# Altium schematic sheet （5）CLOCK & JTAG & VCC.Sch
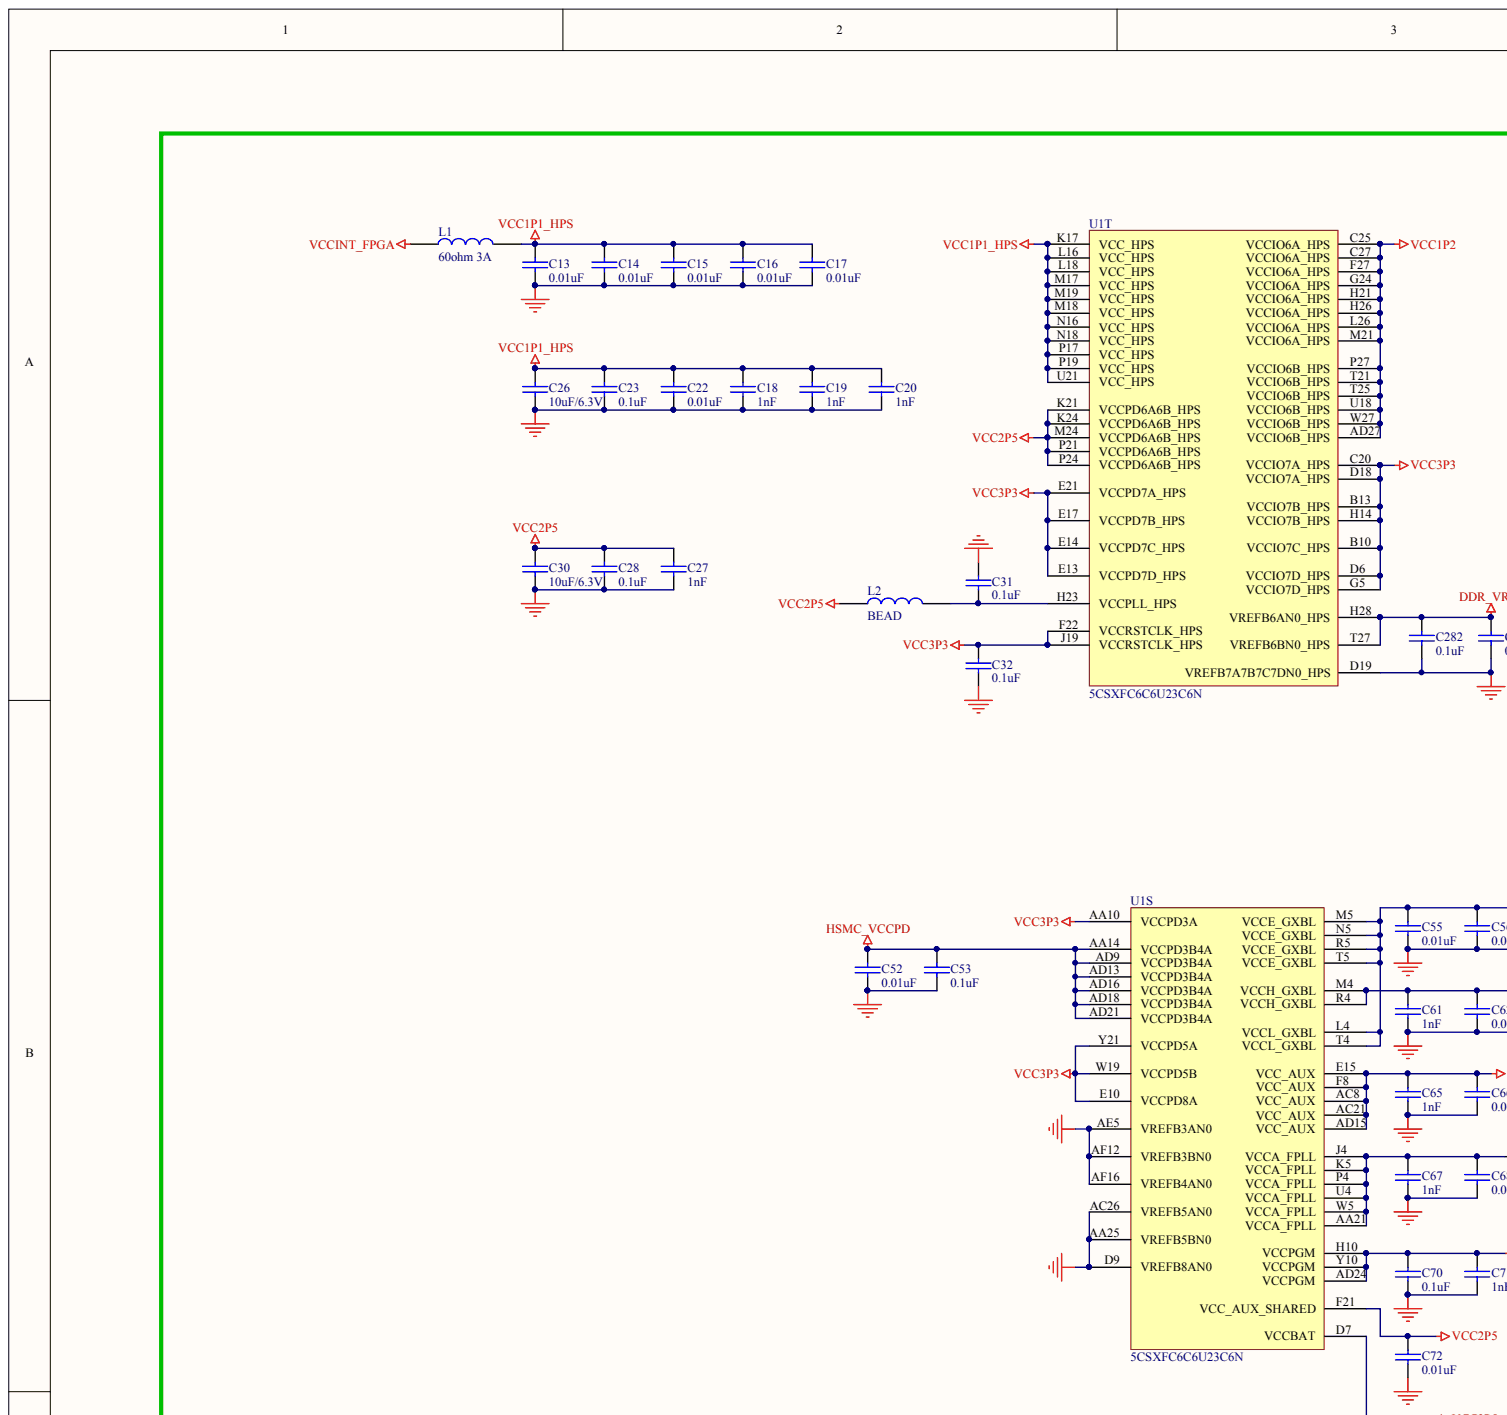
[diagram p0: （5）CLOCK & JTAG & VCC.Sch, top left]
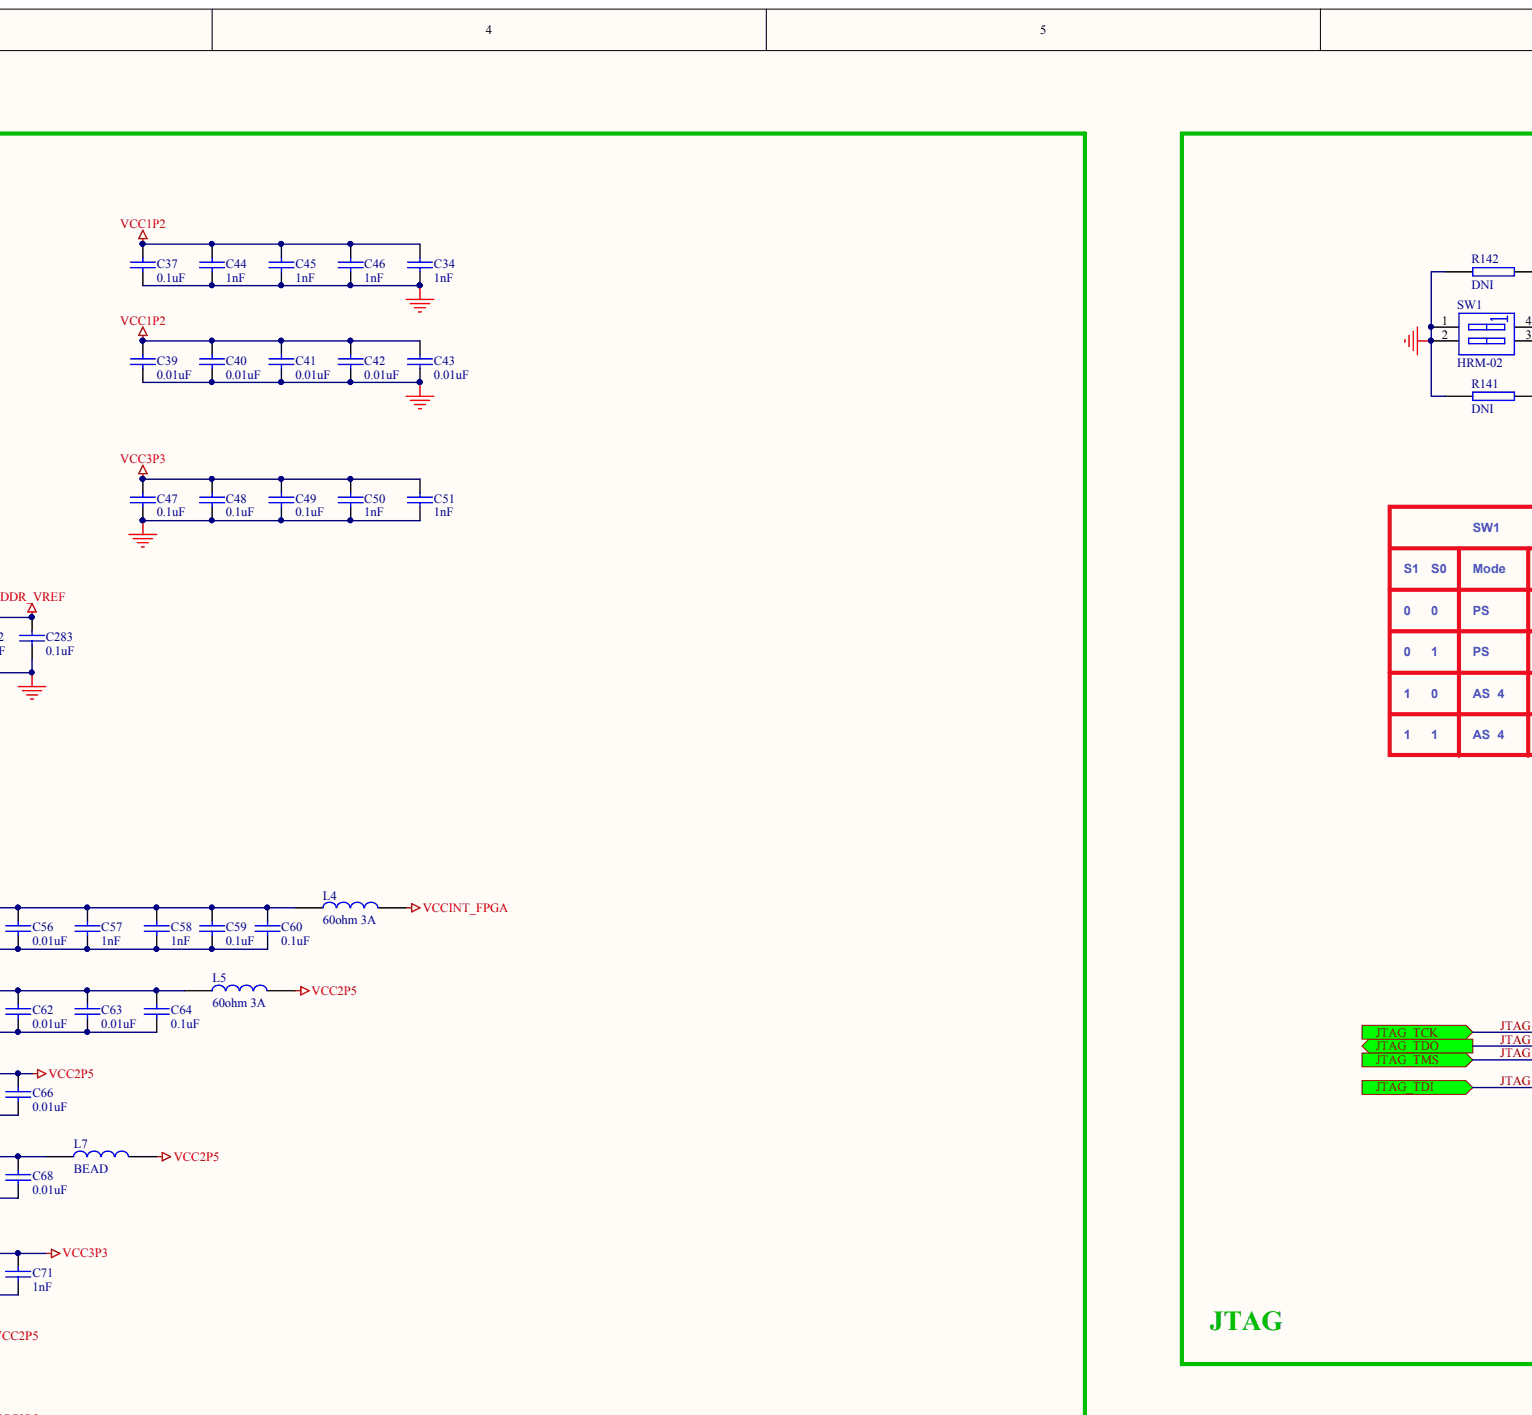
[diagram p1: （5）CLOCK & JTAG & VCC.Sch, top centre]
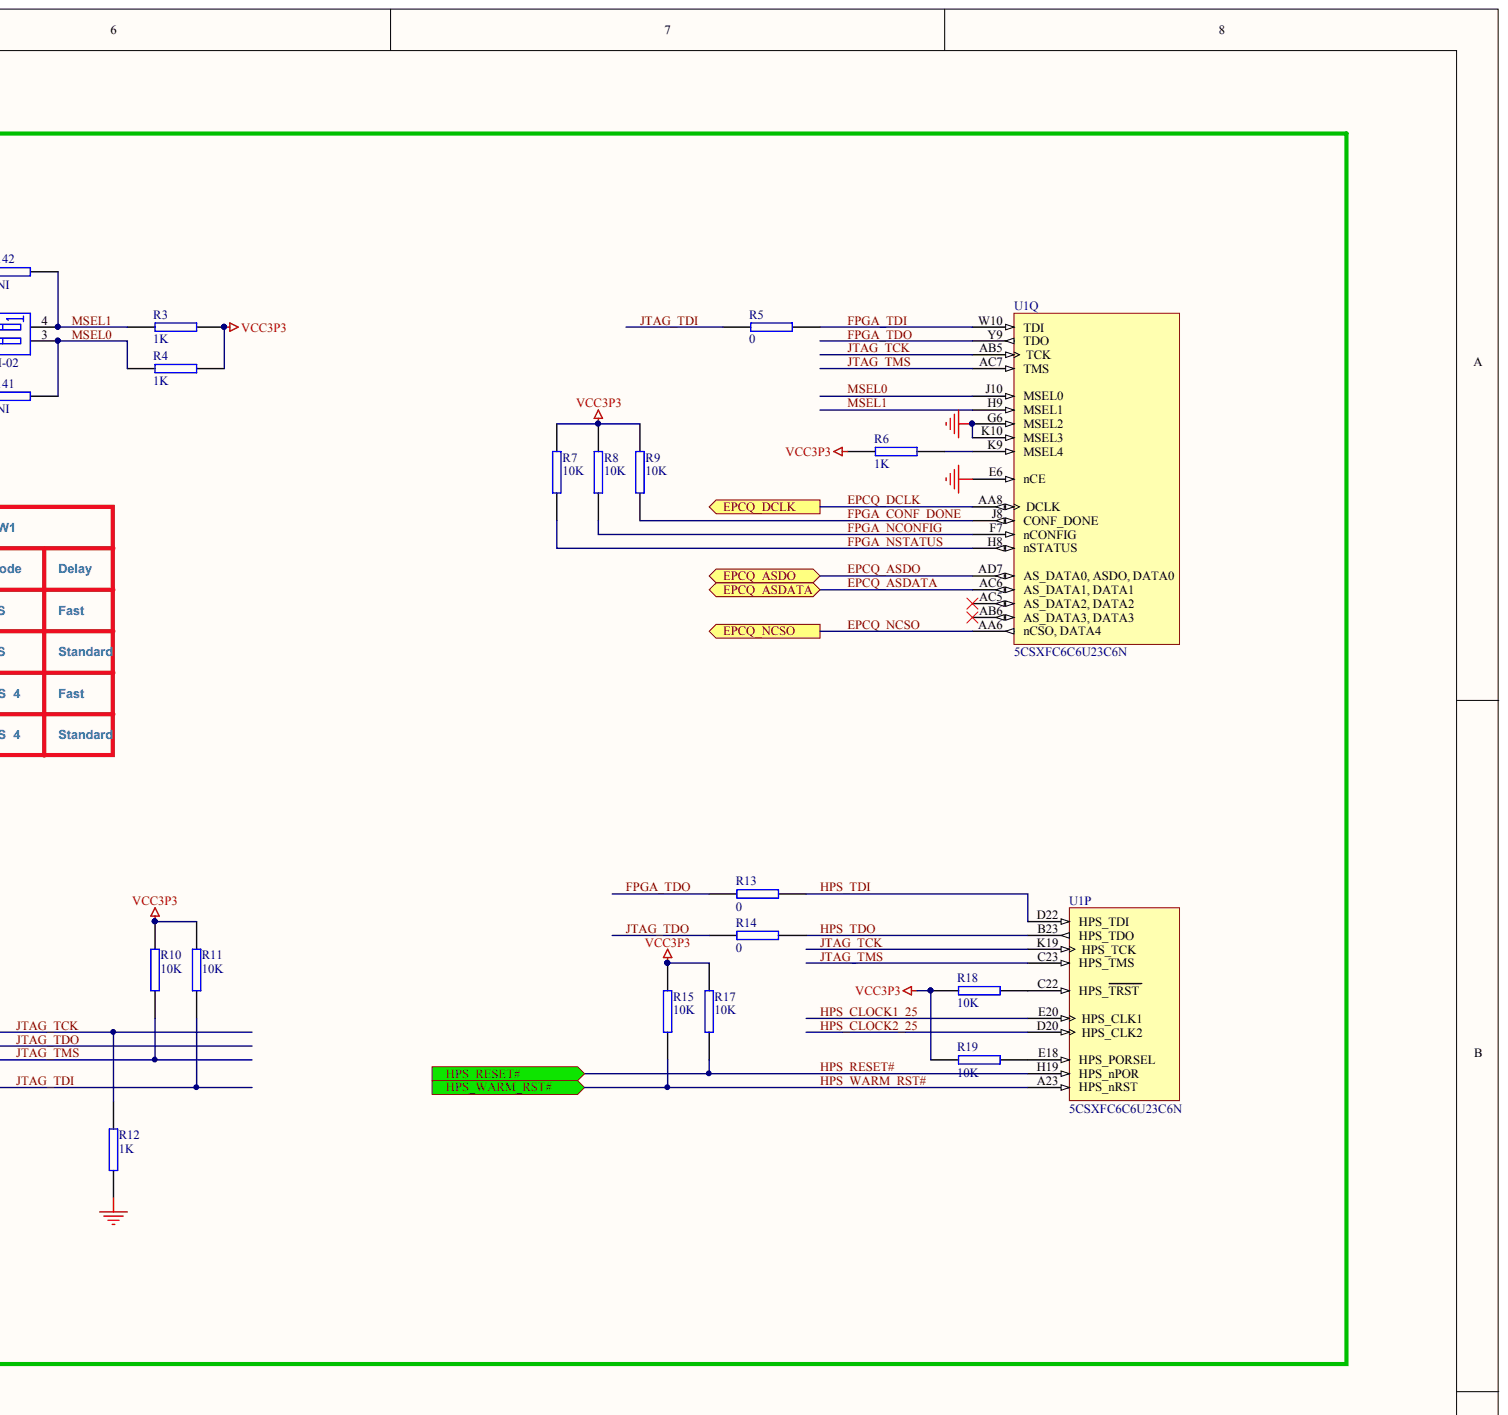
[diagram p2: （5）CLOCK & JTAG & VCC.Sch, top right]
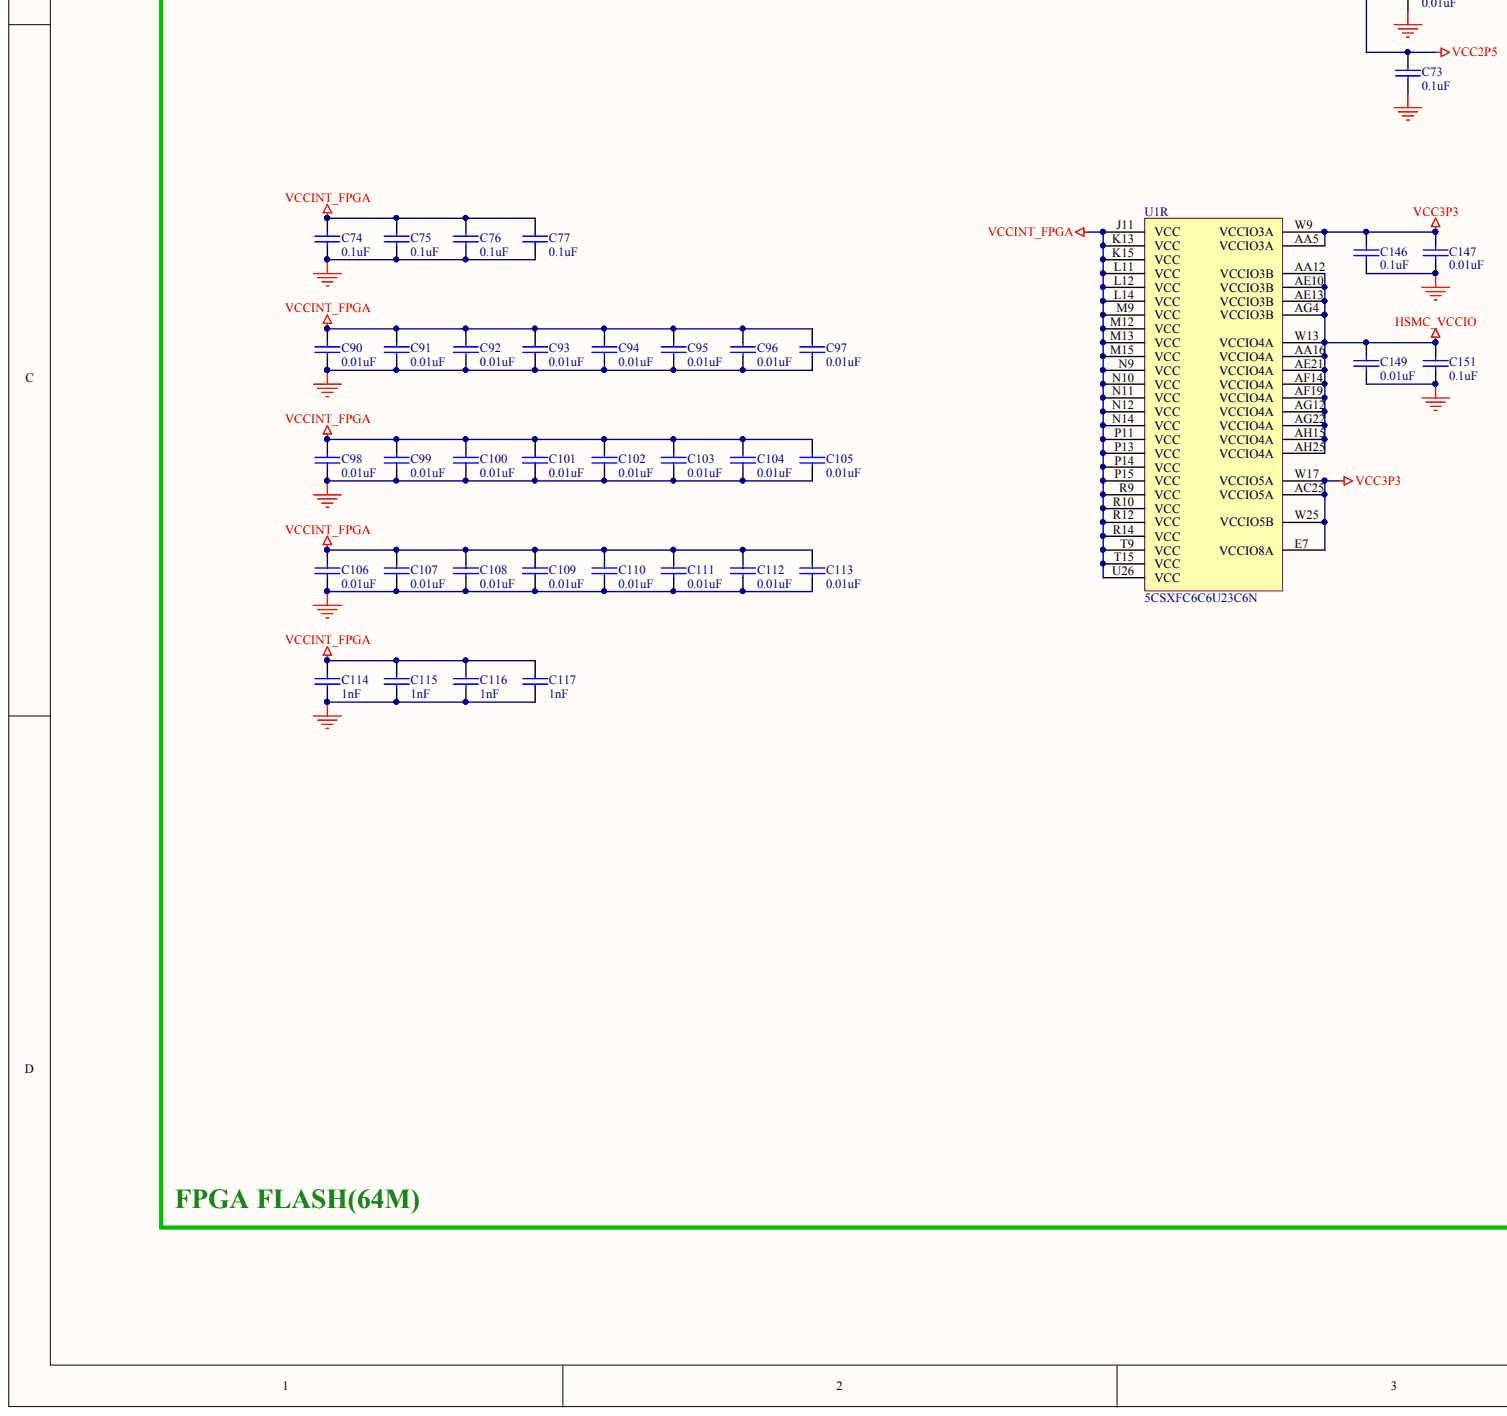
[diagram p3: （5）CLOCK & JTAG & VCC.Sch, bottom left]
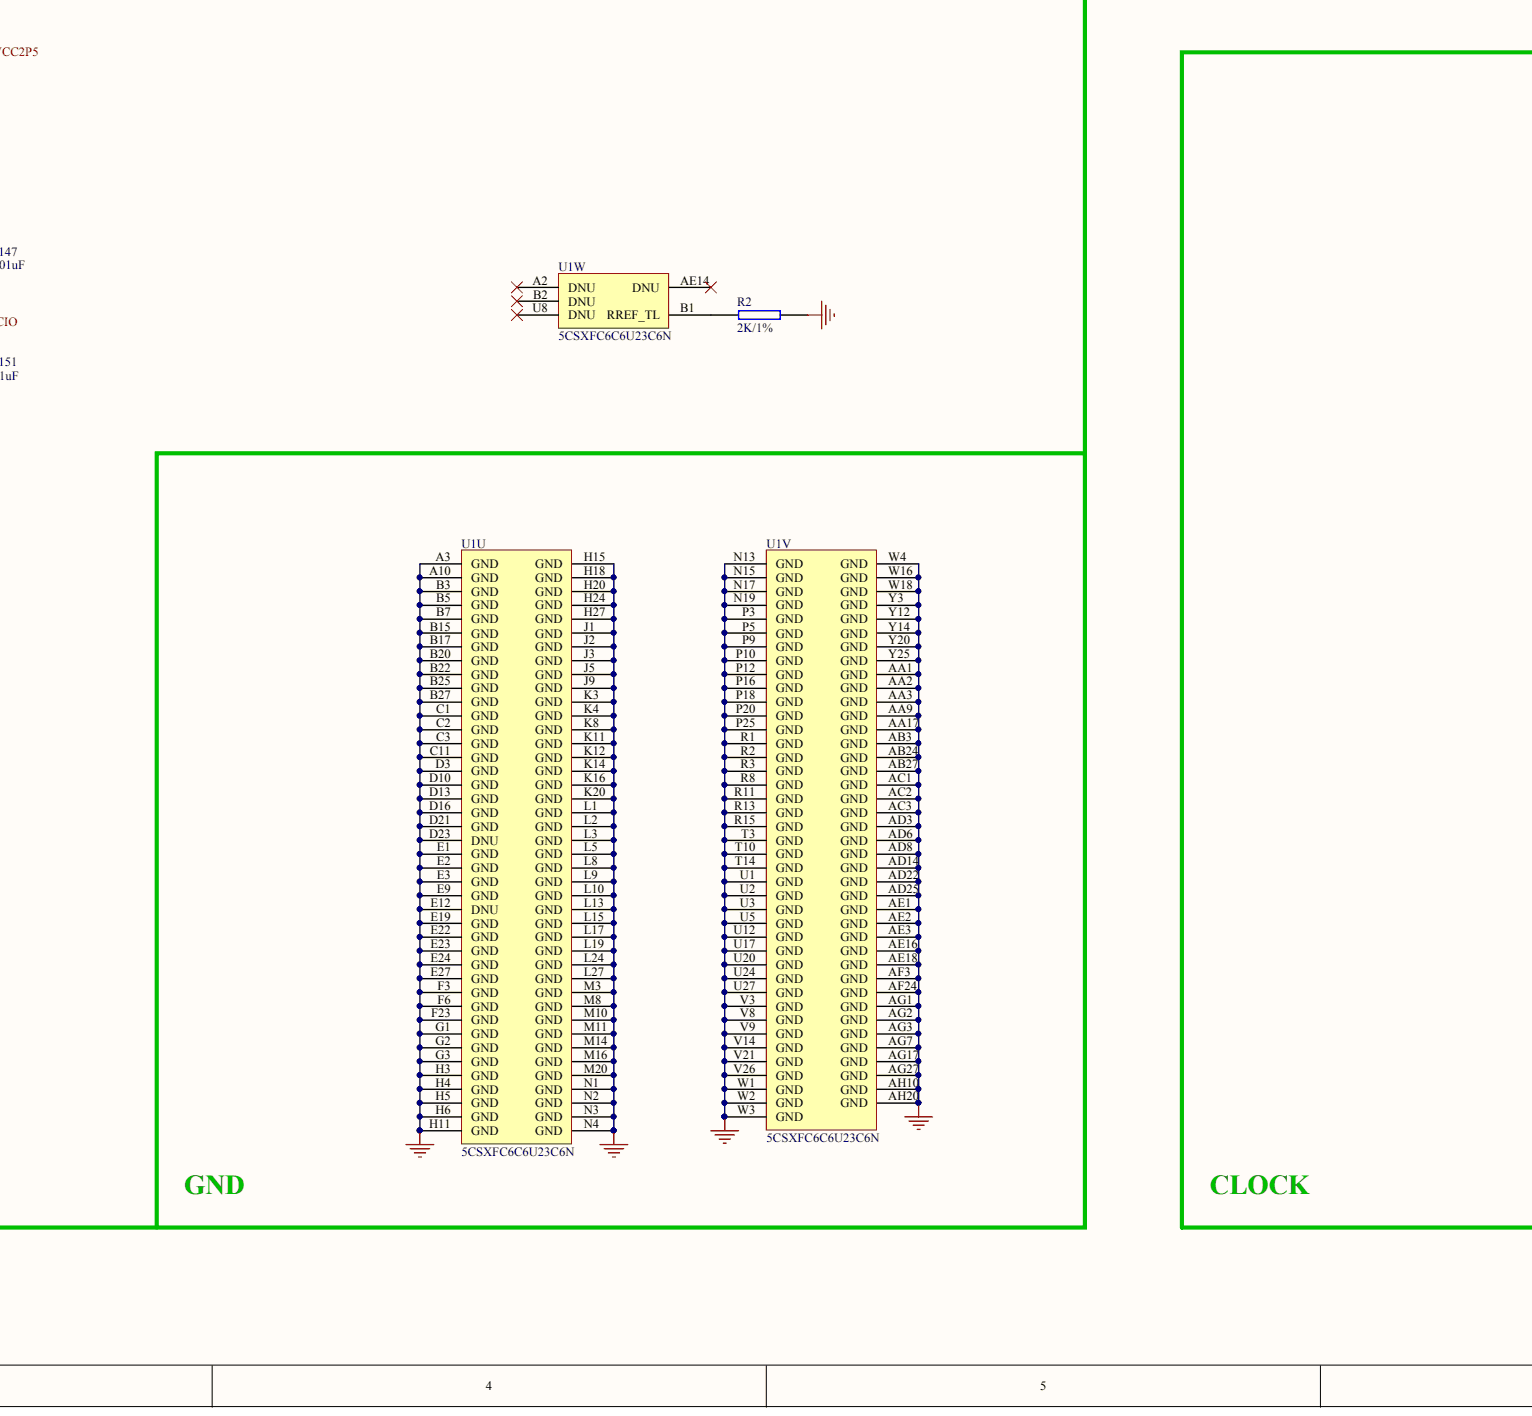
[diagram p4: （5）CLOCK & JTAG & VCC.Sch, bottom centre]
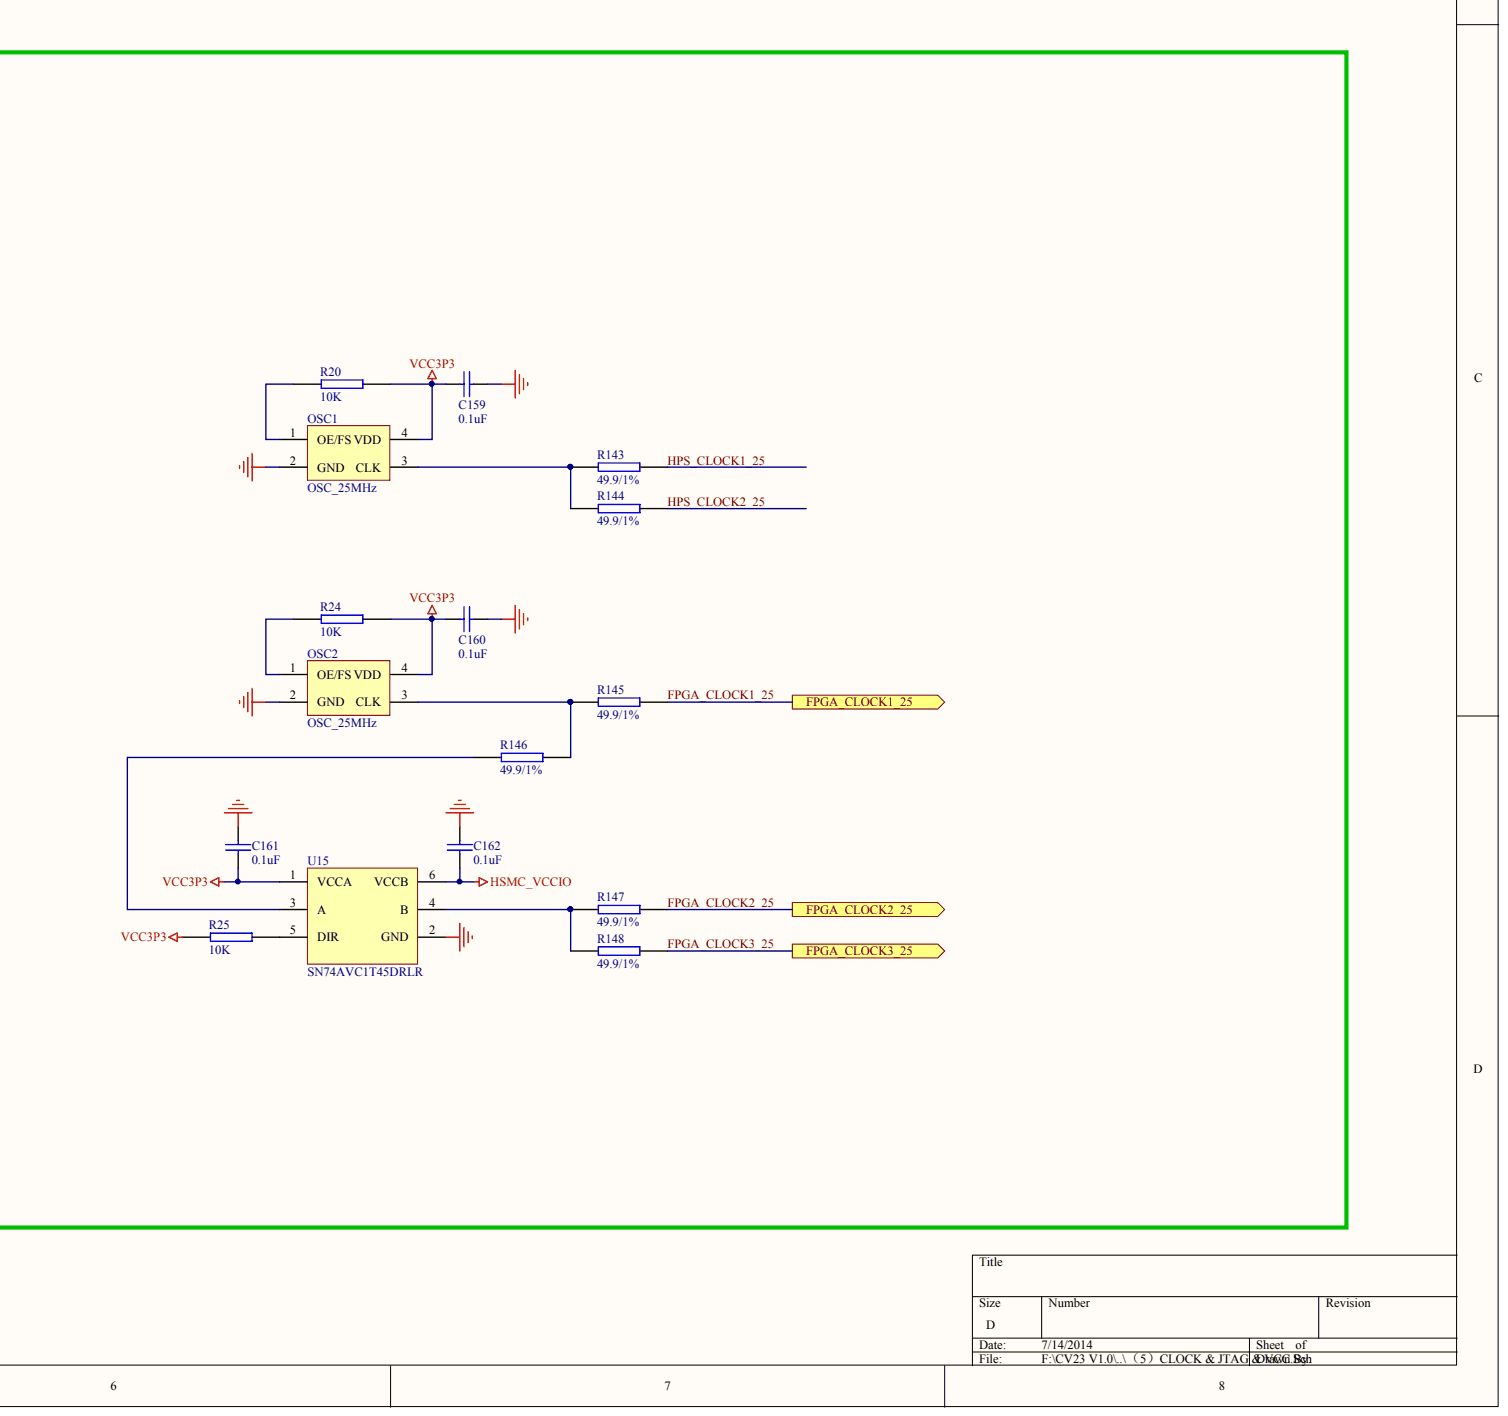
[diagram p5: （5）CLOCK & JTAG & VCC.Sch, bottom right]

## Parts
C13  0.01uF
C14  0.01uF
C15  0.01uF
C16  0.01uF
C17  0.01uF
C18  1nF
C19  1nF
C20  1nF
C22  0.01uF
C23  0.1uF
C26  10uF/6.3V
C27  1nF
C28  0.1uF
C30  10uF/6.3V
C31  0.1uF
C32  0.1uF
C34  1nF
C37  0.1uF
C39  0.01uF
C40  0.01uF
C41  0.01uF
C42  0.01uF
C43  0.01uF
C44  1nF
C45  1nF
C46  1nF
C47  0.1uF
C48  0.1uF
C49  0.1uF
C50  1nF
C51  1nF
C52  0.01uF
C53  0.1uF
C55  0.01uF
C56  0.01uF
C57  1nF
C58  1nF
C59  0.1uF
C60  0.1uF
C61  1nF
C62  0.01uF
C63  0.01uF
C64  0.1uF
C65  1nF
C66  0.01uF
C67  1nF
C68  0.01uF
C70  0.1uF
C71  1nF
C72  0.01uF
C73  0.1uF
C74  0.1uF
C75  0.1uF
C76  0.1uF
C77  0.1uF
C90  0.01uF
C91  0.01uF
C92  0.01uF
C93  0.01uF
C94  0.01uF
C95  0.01uF
C96  0.01uF
C97  0.01uF
C98  0.01uF
C99  0.01uF
C100  0.01uF
C101  0.01uF
C102  0.01uF
C103  0.01uF
C104  0.01uF
C105  0.01uF
C106  0.01uF
C107  0.01uF
C108  0.01uF
C109  0.01uF
C110  0.01uF
C111  0.01uF
C112  0.01uF
C113  0.01uF
C114  1nF
C115  1nF
C116  1nF
C117  1nF
C146  0.1uF
C147  0.01uF
C149  0.01uF
C151  0.1uF
C159  0.1uF
C160  0.1uF
C161  0.1uF
C162  0.1uF
C282  0.1uF
C283  0.1uF
L1  60ohm 3A
L2  BEAD
L4  60ohm 3A
L5  60ohm 3A
L7  BEAD
OSC1  OSC_25MHz
OSC2  OSC_25MHz
R2  2K/1%
R3  1K
R4  1K
R5  0
R6  1K
R7  10K
R8  10K
R9  10K
R10  10K
R11  10K
R12  1K
R13  0
R14  0
R15  10K
R17  10K
R18  10K
R19  10K
R20  10K
R24  10K
R25  10K
R141  DNI
R142  DNI
R143  49.9/1%
R144  49.9/1%
R145  49.9/1%
R146  49.9/1%
R147  49.9/1%
R148  49.9/1%
SW1  HRM-02
U1  5CSXFC6C6U23C6N  (parts P,Q,R,S,T,U,V,W)
U15  SN74AVC1T45DRLR

## Ports
EPCQ_ASDATA, EPCQ_ASDO, EPCQ_DCLK, EPCQ_NCSO, FPGA_CLOCK1_25, FPGA_CLOCK2_25, FPGA_CLOCK3_25, HPS_RESET#, HPS_WARM_RST#, JTAG_TCK, JTAG_TDI, JTAG_TDO, JTAG_TMS

## Nets
DDR_VREF: C282.1 C283.1 U1.H28(VREFB6AN0_HPS) U1.T27(VREFB6BN0_HPS)
GND: C13.2 C14.2 C15.2 C16.2 C17.2 C18.2 C19.2 C20.2 C22.2 C23.2 C26.2 C27.2 C28.2 C30.2 C31.1 C32.2 C34.2 C37.2 C39.2 C40.2 C41.2 C42.2 C43.2 C44.2 C45.2 C46.2 C47.2 C48.2 C49.2 C50.2 C51.2 C52.2 C53.2 C55.2 C56.2 C57.2 C58.2 C59.2 C60.2 C61.2 C62.2 C63.2 C64.2 C65.2 C66.2 C67.2 C68.2 C70.2 C71.2 C72.2 C73.2 C74.2 C75.2 C76.2 C77.2 C90.2 C91.2 C92.2 C93.2 C94.2 C95.2 C96.2 C97.2 C98.2 C99.2 C100.2 C101.2 C102.2 C103.2 C104.2 C105.2 C106.2 C107.2 C108.2 C109.2 C110.2 C111.2 C112.2 C113.2 C114.2 C115.2 C116.2 C117.2 C146.2 C147.2 C149.2 C151.2 C159.2 C160.2 C161.1 C162.1 C282.2 C283.2 OSC1.2(GND) OSC2.2(GND) R2.1 R12.1 R141.2 R142.2 SW1.1 SW1.2 U1.A3(GND) U1.A10(GND) U1.AA1(GND) U1.AA2(GND) U1.AA3(GND) U1.AA9(GND) U1.AA17(GND) U1.AA25(VREFB5BN0) U1.AB3(GND) U1.AB24(GND) U1.AB27(GND) U1.AC1(GND) U1.AC2(GND) U1.AC3(GND) U1.AC26(VREFB5AN0) U1.AD3(GND) U1.AD6(GND) U1.AD8(GND) U1.AD14(GND) U1.AD22(GND) U1.AD25(GND) U1.AE1(GND) U1.AE2(GND) U1.AE3(GND) U1.AE5(VREFB3AN0) U1.AE16(GND) U1.AE18(GND) U1.AF3(GND) U1.AF12(VREFB3BN0) U1.AF16(VREFB4AN0) U1.AF24(GND) U1.AG1(GND) U1.AG2(GND) U1.AG3(GND) U1.AG7(GND) U1.AG17(GND) U1.AG27(GND) U1.AH10(GND) U1.AH20(GND) U1.B3(GND) U1.B5(GND) U1.B7(GND) U1.B15(GND) U1.B17(GND) U1.B20(GND) U1.B22(GND) U1.B25(GND) U1.B27(GND) U1.C1(GND) U1.C2(GND) U1.C3(GND) U1.C11(GND) U1.D3(GND) U1.D9(VREFB8AN0) U1.D10(GND) U1.D13(GND) U1.D16(GND) U1.D19(VREFB7A7B7C7DN0_HPS) U1.D21(GND) U1.D23(DNU) U1.E1(GND) U1.E2(GND) U1.E3(GND) U1.E6(nCE) U1.E9(GND) U1.E12(DNU) U1.E19(GND) U1.E22(GND) U1.E23(GND) U1.E24(GND) U1.E27(GND) U1.F3(GND) U1.F6(GND) U1.F23(GND) U1.G1(GND) U1.G2(GND) U1.G3(GND) U1.G6(MSEL2) U1.H3(GND) U1.H4(GND) U1.H5(GND) U1.H6(GND) U1.H11(GND) U1.H15(GND) U1.H18(GND) U1.H20(GND) U1.H24(GND) U1.H27(GND) U1.J1(GND) U1.J2(GND) U1.J3(GND) U1.J5(GND) U1.J9(GND) U1.K3(GND) U1.K4(GND) U1.K8(GND) U1.K10(MSEL3) U1.K11(GND) U1.K12(GND) U1.K14(GND) U1.K16(GND) U1.K20(GND) U1.L1(GND) U1.L2(GND) U1.L3(GND) U1.L5(GND) U1.L8(GND) U1.L9(GND) U1.L10(GND) U1.L13(GND) U1.L15(GND) U1.L17(GND) U1.L19(GND) U1.L24(GND) U1.L27(GND) U1.M3(GND) U1.M8(GND) U1.M10(GND) U1.M11(GND) U1.M14(GND) U1.M16(GND) U1.M20(GND) U1.N1(GND) U1.N2(GND) U1.N3(GND) U1.N4(GND) U1.N13(GND) U1.N15(GND) U1.N17(GND) U1.N19(GND) U1.P3(GND) U1.P5(GND) U1.P9(GND) U1.P10(GND) U1.P12(GND) U1.P16(GND) U1.P18(GND) U1.P20(GND) U1.P25(GND) U1.R1(GND) U1.R2(GND) U1.R3(GND) U1.R8(GND) U1.R11(GND) U1.R13(GND) U1.R15(GND) U1.T3(GND) U1.T10(GND) U1.T14(GND) U1.U1(GND) U1.U2(GND) U1.U3(GND) U1.U5(GND) U1.U12(GND) U1.U17(GND) U1.U20(GND) U1.U24(GND) U1.U27(GND) U1.V3(GND) U1.V8(GND) U1.V9(GND) U1.V14(GND) U1.V21(GND) U1.V26(GND) U1.W1(GND) U1.W2(GND) U1.W3(GND) U1.W4(GND) U1.W16(GND) U1.W18(GND) U1.Y3(GND) U1.Y12(GND) U1.Y14(GND) U1.Y20(GND) U1.Y25(GND) U15.2(GND)
HSMC_VCCIO: C149.1 C151.1 C162.2 U1.AA12(VCCIO3B) U1.AA16(VCCIO4A) U1.AE10(VCCIO3B) U1.AE13(VCCIO3B) U1.AE21(VCCIO4A) U1.AF14(VCCIO4A) U1.AF19(VCCIO4A) U1.AG4(VCCIO3B) U1.AG12(VCCIO4A) U1.AG22(VCCIO4A) U1.AH15(VCCIO4A) U1.AH25(VCCIO4A) U1.W13(VCCIO4A) U15.6(VCCB)
HSMC_VCCPD: C52.1 C53.1 U1.AA14(VCCPD3B4A) U1.AD9(VCCPD3B4A) U1.AD13(VCCPD3B4A) U1.AD16(VCCPD3B4A) U1.AD18(VCCPD3B4A) U1.AD21(VCCPD3B4A)
VCC1P1_HPS: C13.1 C14.1 C15.1 C16.1 C17.1 C18.1 C19.1 C20.1 C22.1 C23.1 C26.1 L1.2 U1.K17(VCC_HPS) U1.L16(VCC_HPS) U1.L18(VCC_HPS) U1.M17(VCC_HPS) U1.M18(VCC_HPS) U1.M19(VCC_HPS) U1.N16(VCC_HPS) U1.N18(VCC_HPS) U1.P17(VCC_HPS) U1.P19(VCC_HPS) U1.U21(VCC_HPS)
VCC1P2: C34.1 C37.1 C39.1 C40.1 C41.1 C42.1 C43.1 C44.1 C45.1 C46.1 U1.AD27(VCCIO6B_HPS) U1.C25(VCCIO6A_HPS) U1.C27(VCCIO6A_HPS) U1.F27(VCCIO6A_HPS) U1.G24(VCCIO6A_HPS) U1.H21(VCCIO6A_HPS) U1.H26(VCCIO6A_HPS) U1.L26(VCCIO6A_HPS) U1.M21(VCCIO6A_HPS) U1.P27(VCCIO6B_HPS) U1.T21(VCCIO6B_HPS) U1.T25(VCCIO6B_HPS) U1.U18(VCCIO6B_HPS) U1.W27(VCCIO6B_HPS)
VCC2P5: C27.1 C28.1 C30.1 C65.1 C66.1 C72.1 C73.1 L2.1 L5.2 L7.2 U1.AC8(VCC_AUX) U1.AC21(VCC_AUX) U1.AD15(VCC_AUX) U1.D7(VCCBAT) U1.E15(VCC_AUX) U1.F8(VCC_AUX) U1.F21(VCC_AUX_SHARED) U1.K21(VCCPD6A6B_HPS) U1.K24(VCCPD6A6B_HPS) U1.M24(VCCPD6A6B_HPS) U1.P21(VCCPD6A6B_HPS) U1.P24(VCCPD6A6B_HPS)
VCC3P3: C32.1 C47.1 C48.1 C49.1 C50.1 C51.1 C70.1 C71.1 C146.1 C147.1 C159.1 C160.1 C161.2 OSC1.4(VDD) OSC2.4(VDD) R3.1 R4.1 R6.2 R7.2 R8.2 R9.2 R10.2 R11.2 R15.2 R17.1 R18.2 R19.2 R20.1 R24.1 R25.2 U1.AA5(VCCIO3A) U1.AA10(VCCPD3A) U1.AC25(VCCIO5A) U1.AD24(VCCPGM) U1.B10(VCCIO7C_HPS) U1.B13(VCCIO7B_HPS) U1.C20(VCCIO7A_HPS) U1.D6(VCCIO7D_HPS) U1.D18(VCCIO7A_HPS) U1.E7(VCCIO8A) U1.E10(VCCPD8A) U1.E13(VCCPD7D_HPS) U1.E14(VCCPD7C_HPS) U1.E17(VCCPD7B_HPS) U1.E21(VCCPD7A_HPS) U1.F22(VCCRSTCLK_HPS) U1.G5(VCCIO7D_HPS) U1.H10(VCCPGM) U1.H14(VCCIO7B_HPS) U1.J19(VCCRSTCLK_HPS) U1.W9(VCCIO3A) U1.W17(VCCIO5A) U1.W19(VCCPD5B) U1.W25(VCCIO5B) U1.Y10(VCCPGM) U1.Y21(VCCPD5A) U15.1(VCCA)
VCCINT_FPGA: C74.1 C75.1 C76.1 C77.1 C90.1 C91.1 C92.1 C93.1 C94.1 C95.1 C96.1 C97.1 C98.1 C99.1 C100.1 C101.1 C102.1 C103.1 C104.1 C105.1 C106.1 C107.1 C108.1 C109.1 C110.1 C111.1 C112.1 C113.1 C114.1 C115.1 C116.1 C117.1 L1.1 L4.2 U1.J11(VCC) U1.K13(VCC) U1.K15(VCC) U1.L11(VCC) U1.L12(VCC) U1.L14(VCC) U1.M9(VCC) U1.M12(VCC) U1.M13(VCC) U1.M15(VCC) U1.N9(VCC) U1.N10(VCC) U1.N11(VCC) U1.N12(VCC) U1.N14(VCC) U1.P11(VCC) U1.P13(VCC) U1.P14(VCC) U1.P15(VCC) U1.R9(VCC) U1.R10(VCC) U1.R12(VCC) U1.R14(VCC) U1.T9(VCC) U1.T15(VCC) U1.U26(VCC)
EPCQ_ASDATA: U1.AC6(AS_DATA1,_DATA1) ; port EPCQ_ASDATA
EPCQ_ASDO: U1.AD7(AS_DATA0,_ASDO,_DATA0) ; port EPCQ_ASDO
EPCQ_DCLK: U1.AA8(DCLK) ; port EPCQ_DCLK
EPCQ_NCSO: U1.AA6(nCSO,_DATA4) ; port EPCQ_NCSO
FPGA_CLOCK1_25: R145.2 ; port FPGA_CLOCK1_25
FPGA_CLOCK2_25: R147.2 ; port FPGA_CLOCK2_25
FPGA_CLOCK3_25: R148.1 ; port FPGA_CLOCK3_25
FPGA_CONF_DONE: R9.1 U1.J8(CONF_DONE)
FPGA_NCONFIG: R8.1 U1.F7(nCONFIG)
FPGA_NSTATUS: R7.1 U1.H8(nSTATUS)
FPGA_TDI: R5.1 U1.W10(TDI)
FPGA_TDO: R13.2 U1.Y9(TDO)
HPS_CLOCK1_25: R143.2 U1.E20(HPS_CLK1)
HPS_CLOCK2_25: R144.1 U1.D20(HPS_CLK2)
HPS_RESET#: R17.2 U1.H19(HPS_nPOR) ; port HPS_RESET#
HPS_TDI: R13.1 U1.D22(HPS_TDI)
HPS_TDO: R14.1 U1.B23(HPS_TDO)
HPS_WARM_RST#: R15.1 U1.A23(HPS_nRST) ; port HPS_WARM_RST#
JTAG_TCK: R12.2 U1.AB5(TCK) U1.K19(HPS_TCK) ; port JTAG_TCK
JTAG_TDI: R5.2 R11.1 ; port JTAG_TDI
JTAG_TDO: R14.2 ; port JTAG_TDO
JTAG_TMS: R10.1 U1.AC7(TMS) U1.C23(HPS_TMS) ; port JTAG_TMS
MSEL0: R4.2 R141.1 SW1.3 U1.J10(MSEL0)
MSEL1: R3.2 R142.1 SW1.4 U1.H9(MSEL1)
NetC31_2: C31.2 L2.2 U1.H23(VCCPLL_HPS)
NetC55_1: C55.1 C56.1 C57.1 C58.1 C59.1 C60.1 L4.1 U1.L4(VCCL_GXBL) U1.M5(VCCE_GXBL) U1.N5(VCCE_GXBL) U1.R5(VCCE_GXBL) U1.T4(VCCL_GXBL) U1.T5(VCCE_GXBL)
NetC61_1: C61.1 C62.1 C63.1 C64.1 L5.1 U1.M4(VCCH_GXBL) U1.R4(VCCH_GXBL)
NetC67_1: C67.1 C68.1 L7.1 U1.AA21(VCCA_FPLL) U1.J4(VCCA_FPLL) U1.K5(VCCA_FPLL) U1.P4(VCCA_FPLL) U1.U4(VCCA_FPLL) U1.W5(VCCA_FPLL)
NetOSC1_1: OSC1.1(OE/FS) R20.2
NetOSC1_3: OSC1.3(CLK) R143.1 R144.2
NetOSC2_1: OSC2.1(OE/FS) R24.2
NetOSC2_3: OSC2.3(CLK) R145.1 R146.2
NetR2_2: R2.2 U1.B1(RREF_TL)
NetR6_1: R6.1 U1.K9(MSEL4)
NetR18_1: R18.1 U1.C22(HPS_TRST)
NetR19_1: R19.1 U1.E18(HPS_PORSEL)
NetR25_1: R25.1 U15.5(DIR)
NetR146_1: R146.1 U15.3(A)
NetR147_1: R147.1 R148.2 U15.4(B)

Not connected: U1 A2(DNU) AB6(AS_DATA3,_DATA3) AC5(AS_DATA2,_DATA2) AE14(DNU) B2(DNU) U8(DNU)

## Library data of the parts
C13-C20 C22 C23 C26-C28 C30-C32 C34 C37 C39-C53 C55-C68 C70-C77 C90-C117 C146 C147 C149 C151 C159-C162 C282 C283 L1 L2 L4 L5 L7 OSC1 OSC2 R2-R15 R17-R20 R24 R25 R141-R148 SW1 U1 U15 = (no symbol name)
  U1: DatasheetVersion: *; PackageVersion: *; PackageReference: *; PackageDescription: *; Supplier 1: *; Supplier Part Number 1: *; Supplier 2: *; Supplier Part Number 2: *; Device Group: *; Logic Elements (LE) (K): *; RoHS: *; Speed Grade: *; Subcategory: *; Voltage Supply: *; NexusDeviceID: *; Manufacturer: *
